# Altium schematic sheet BM20_Rev0_IC.SchDoc
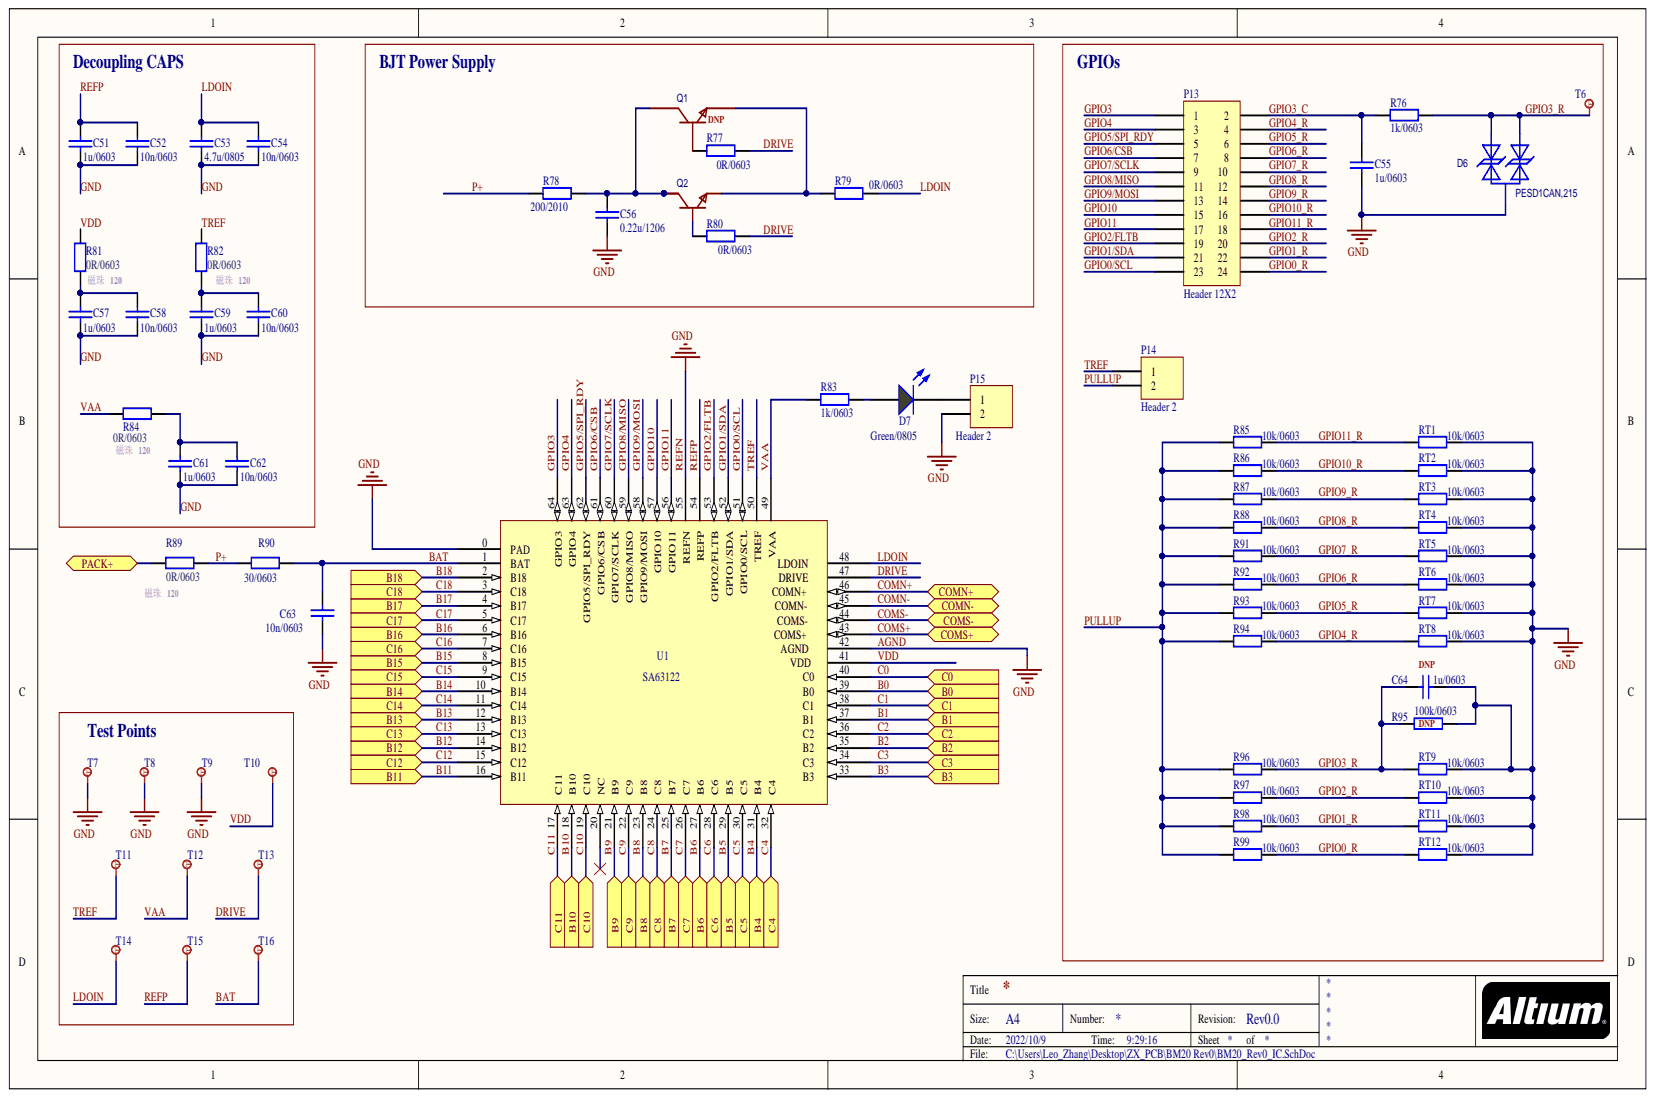
[diagram p0: BM20_Rev0_IC.SchDoc]

## Parts
C51  1u/0603  [0603_C]
C52  10n/0603  [0603_C]
C53  4.7u/0805  [0805_R]
C54  10n/0603  [0603_C]
C55  1u/0603  [0603_C]
C56  0.22u/1206  [1206_C]
C57  1u/0603  [0603_C]
C58  10n/0603  [0603_C]
C59  1u/0603  [0603_C]
C60  10n/0603  [0603_C]
C61  1u/0603  [0603_C]
C62  10n/0603  [0603_C]
C63  10n/0603  [0603_C]
C64  1u/0603  [0603_C]
D6  PESD1CAN,215  [SOT-23]
D7  Green/0805  [0805_LED]
P13  Header 12X2  [HDR2X12]
P14  Header 2  [HDR1X2]
P15  Header 2  [HDR1X2]
Q1  ZXTN4004KTC  [TO-252-3]  (value not drawn)
Q2  CZT5551_C513469  [SOT-223]  (value not drawn)
R76  1k/0603  [0603_R]
R77  0R/0603  [0603_R]
R78  200/2010  [2010_R]
R79  0R/0603  [0603_R]
R80  0R/0603  [0603_R]
R81  0R/0603  [0603_R]
R82  0R/0603  [0603_R]
R83  1k/0603  [0603_R]
R84  0R/0603  [0603_R]
R85  10k/0603  [0603_R]
R86  10k/0603  [0603_R]
R87  10k/0603  [0603_R]
R88  10k/0603  [0603_R]
R89  0R/0603  [0603_R]
R90  30/0603  [0603_R]
R91  10k/0603  [0603_R]
R92  10k/0603  [0603_R]
R93  10k/0603  [0603_R]
R94  10k/0603  [0603_R]
R95  100k/0603  [0603_R]
R96  10k/0603  [0603_R]
R97  10k/0603  [0603_R]
R98  10k/0603  [0603_R]
R99  10k/0603  [0603_R]
RT1  10k/0603  [0603_R]
RT2  10k/0603  [0603_R]
RT3  10k/0603  [0603_R]
RT4  10k/0603  [0603_R]
RT5  10k/0603  [0603_R]
RT6  10k/0603  [0603_R]
RT7  10k/0603  [0603_R]
RT8  10k/0603  [0603_R]
RT9  10k/0603  [0603_R]
RT10  10k/0603  [0603_R]
RT11  10k/0603  [0603_R]
RT12  10k/0603  [0603_R]
T6  Test  [TP_Point]  (value not drawn)
T7  Test  [TP_GND]
T8  Test  [TP_GND]
T9  Test  [TP_GND]  (value not drawn)
T10  Test  [TP_Point]  (value not drawn)
T11  Test  [TP_Point]  (value not drawn)
T12  Test  [TP_Point]  (value not drawn)
T13  Test  [TP_Point]  (value not drawn)
T14  Test  [TP_Point]  (value not drawn)
T15  Test  [TP_Point]  (value not drawn)
T16  Test  [TP_Point]  (value not drawn)
U1  SA63122  [LQFP10x10-64L]

## Ports
B0, B1, B2, B3, B4, B5, B6, B7, B8, B9, B10, B11, B12, B13, B14, B15, B16, B17, B18, C0, C1, C2, C3, C4, C5, C6, C7, C8, C9, C10, C11, C12, C13, C14, C15, C16, C17, C18, COMN+, COMN-, COMS+, COMS-, PACK+

## Nets
AGND: C51.1 C52.1 C53.1 C54.1 C55.1 C56.1 C57.1 C58.1 C59.1 C60.1 C61.1 C62.1 C63.2 C64.1 D6.3 P15.2 R95.2 RT1.2 RT2.2 RT3.2 RT4.2 RT5.2 RT6.2 RT7.2 RT8.2 RT9.2 RT10.2 RT11.2 RT12.2 T7.0 T8.0 T9.0 U1.0(PAD) U1.42(AGND) U1.55(REFN)
B0: U1.39(B0) ; port B0
B1: U1.37(B1) ; port B1
B2: U1.35(B2) ; port B2
B3: U1.33(B3) ; port B3
B4: U1.31(B4) ; port B4
B5: U1.29(B5) ; port B5
B6: U1.27(B6) ; port B6
B7: U1.25(B7) ; port B7
B8: U1.23(B8) ; port B8
B9: U1.21(B9) ; port B9
B10: U1.18 ; port B10
B11: U1.16(B11) ; port B11
B12: U1.14(B12) ; port B12
B13: U1.12(B13) ; port B13
B14: U1.10(B14) ; port B14
B15: U1.8(B15) ; port B15
B16: U1.6(B16) ; port B16
B17: U1.4(B17) ; port B17
B18: U1.2(B18) ; port B18
BAT: C63.1 R90.2 T16.0 U1.1(BAT)
C0: U1.40(C0) ; port C0
C1: U1.38(C1) ; port C1
C2: U1.36(C2) ; port C2
C3: U1.34(C3) ; port C3
C4: U1.32 ; port C4
C5: U1.30(C5) ; port C5
C6: U1.28(C6) ; port C6
C7: U1.26(C7) ; port C7
C8: U1.24(C8) ; port C8
C9: U1.22(C9) ; port C9
C10: U1.19(C10) ; port C10
C11: U1.17(C11) ; port C11
C12: U1.15(C12) ; port C12
C13: U1.13(C13) ; port C13
C14: U1.11(C14) ; port C14
C15: U1.9(C15) ; port C15
C16: U1.7(C16) ; port C16
C17: U1.5(C17) ; port C17
C18: U1.3(C18) ; port C18
COMN+: U1.46(COMN+) ; port COMN+
COMN-: U1.45(COMN-) ; port COMN-
COMS+: U1.43(COMS+) ; port COMS+
COMS-: U1.44(COMS-) ; port COMS-
DRIVE: R77.2 R80.2 T13.0 U1.47(DRIVE)
GPIO0/SCL: P13.23 U1.51(GPIO0/SCL)
GPIO0_R: P13.24 R99.2 RT12.1
GPIO1/SDA: P13.21 U1.52(GPIO1/SDA)
GPIO1_R: P13.22 R98.2 RT11.1
GPIO2/FLTB: P13.19 U1.53(GPIO2/FLTB)
GPIO2_R: P13.20 R97.2 RT10.1
GPIO3: P13.1 U1.64(GPIO3)
GPIO3_C: C55.2 P13.2 R76.1
GPIO3_R: C64.2 D6.1 D6.2 R76.2 R95.1 R96.2 RT9.1 T6.0
GPIO4: P13.3 U1.63
GPIO4_R: P13.4 R94.2 RT8.1
GPIO5/SPI_RDY: P13.5 U1.62(GPIO5/SPI_RDY)
GPIO5_R: P13.6 R93.2 RT7.1
GPIO6/CSB: P13.7 U1.61(GPIO6/CSB)
GPIO6_R: P13.8 R92.2 RT6.1
GPIO7/SCLK: P13.9 U1.60(GPIO7/SCLK)
GPIO7_R: P13.10 R91.2 RT5.1
GPIO8/MISO: P13.11 U1.59(GPIO8/MISO)
GPIO8_R: P13.12 R88.2 RT4.1
GPIO9/MOSI: P13.13 U1.58(GPIO9/MOSI)
GPIO9_R: P13.14 R87.2 RT3.1
GPIO10: P13.15 U1.57(GPIO10)
GPIO10_R: P13.16 R86.2 RT2.1
GPIO11: P13.17 U1.56(GPIO11)
GPIO11_R: P13.18 R85.2 RT1.1
LDOIN: C53.2 C54.2 R79.2 T14.0 U1.48(LDOIN)
P+: R78.1 R89.2 R90.1
PACK+: R89.1 ; port PACK+
PULLUP: P14.2 R85.1 R86.1 R87.1 R88.1 R91.1 R92.1 R93.1 R94.1 R96.1 R97.1 R98.1 R99.1
REFP: C51.2 C52.2 T15.0 U1.54(REFP)
TREF: P14.1 R82.1 T11.0 U1.50(TREF)
VAA: R83.1 R84.2 T12.0 U1.49
VDD: R81.1 T10.0 U1.41(VDD)
NetC56_2: C56.2 Q1.2 Q2.2 Q2.4 R78.2
NetC57_2: C57.2 C58.2 R81.2
NetC59_2: C59.2 C60.2 R82.2
NetC61_2: C61.2 C62.2 R84.1
NetD7_A: D7.A R83.2
NetD7_K: D7.K P15.1
NetQ1_1: Q1.1 R77.1
NetQ1_3: Q1.3 Q2.3 R79.1
NetQ2_1: Q2.1 R80.1

Not connected: U1 20(NC)

## Library data of the parts
C51-C64 = capacitor: 贴片电容; Component Kind: Standard; Library Name: Schlib1.SchLib
D6 = PESD1CAN_C343993: Component Kind: Standard; Library Name: BM02 Rev0.SchLib
D7 = LED: 发光二极管; Component Kind: Standard; Library Name: Schlib1.SchLib
P13 = Header 12X2: Header, 12-Pin, Dual row; Component Kind: Standard; LatestRevisionDate: 17-Jul-2002; LatestRevisionNote: Re-released for DXP Platform.; Library Name: Miscellaneous Connectors.IntLib; Signal Integrity: Connector; Publisher: Altium Limited
P14 P15 = Header 2: Header, 2-Pin; Component Kind: Standard; Library Name: Miscellaneous Connectors.IntLib; Signal Integrity: Connector
Q1 = ZXTN4004KTC: BOM_Manufacturer: Diodes Incorporated; Contributor: LCSC; BOM_JLCPCB Part Class: Extended Part; BOM_Manufacturer Part: ZXTN4004KTC; BOM_Supplier: LCSC; BOM_Supplier Part: C157603; Component Kind: Standard; spicePre: Q; spiceSymbolName: ZXTN4004KTC
Q2 = CZT5551_C513469: BOM_Manufacturer: Slkor(SLKORMICRO Elec.); Contributor: LCSC; BOM_JLCPCB Part Class: Extended Part; BOM_Manufacturer Part: CZT5551; BOM_Supplier: LCSC; BOM_Supplier Part: C513469; Component Kind: Standard; spicePre: Q; spiceSymbolName: CZT5551_C513469
R76-R99 RT1-RT12 = Resistor: 贴片电阻; Component Kind: Standard; Library Name: Schlib1.SchLib
U1 = BM20 Rev0: Component Kind: Standard; Library Name: BM20 Rev0.SchLib
T6-T16 = Test: Component Kind: Standard; Library Name: 17S.SchLib
